# Altium schematic sheet Inresol_grid_inverter_EMI-Filter_GRID_UI_Rev3B.SchDoc
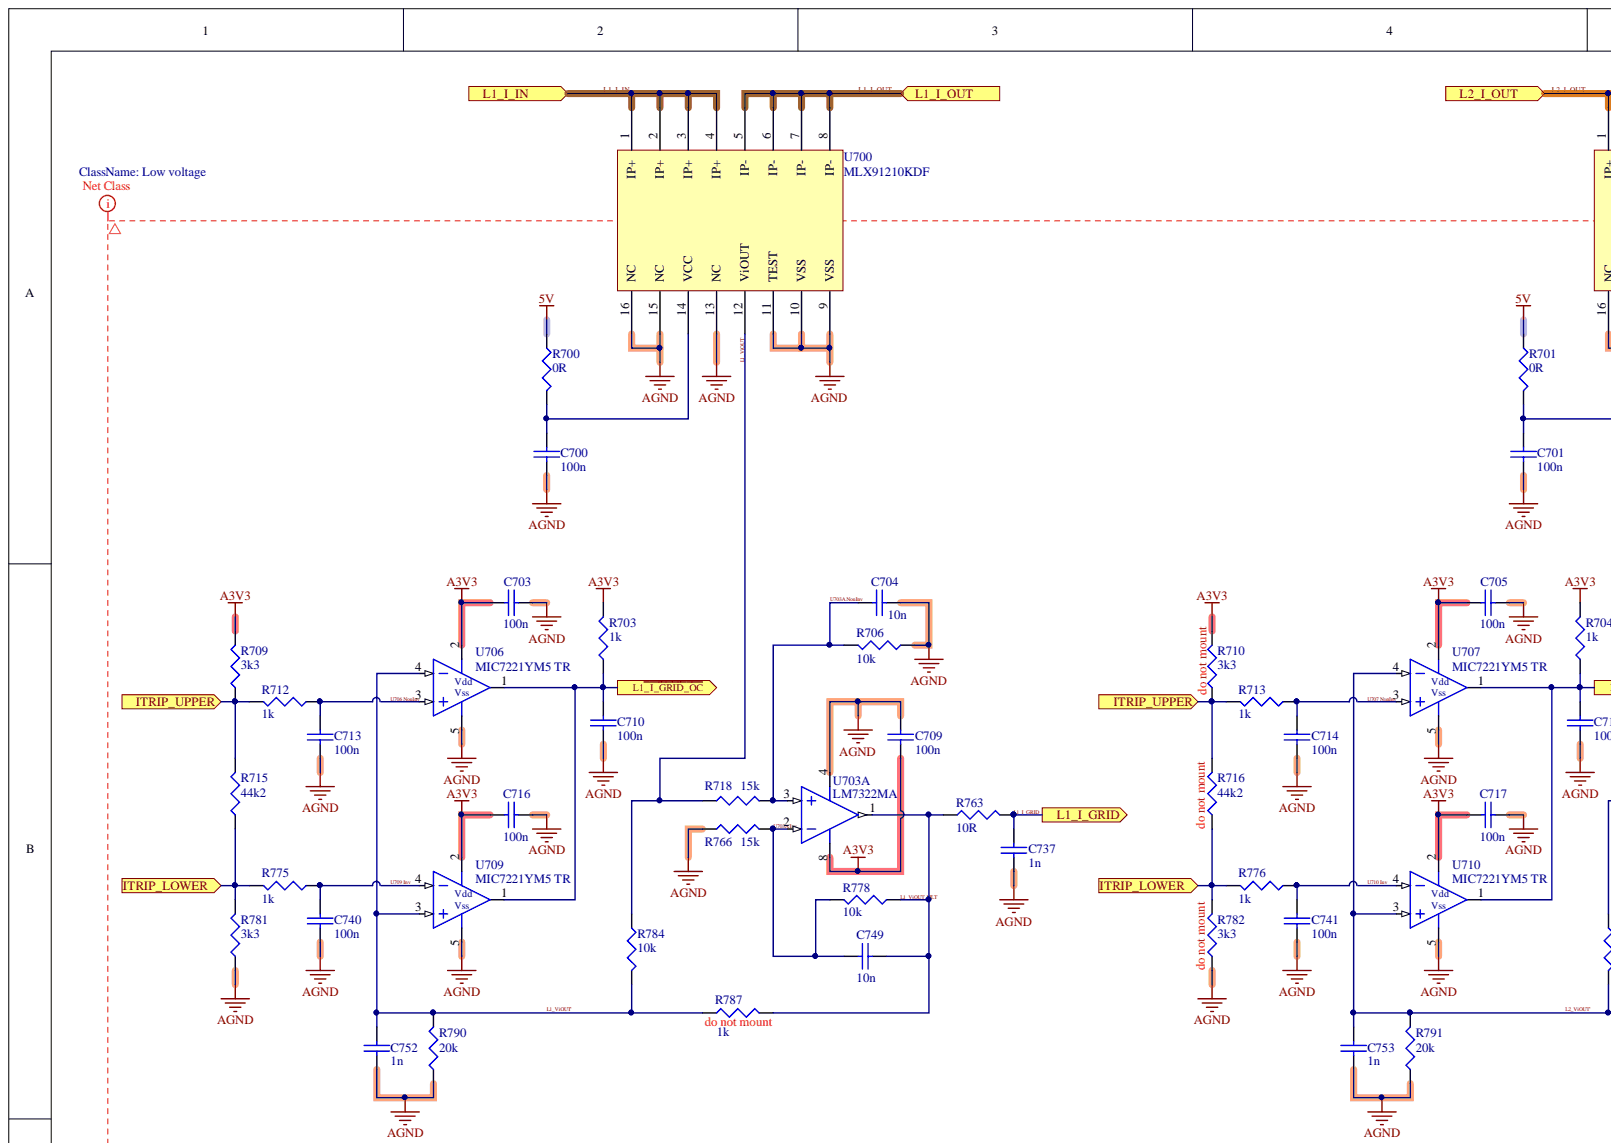
[diagram p0: Inresol_grid_inverter_EMI-Filter_GRID_UI_Rev3B.SchDoc, top left]
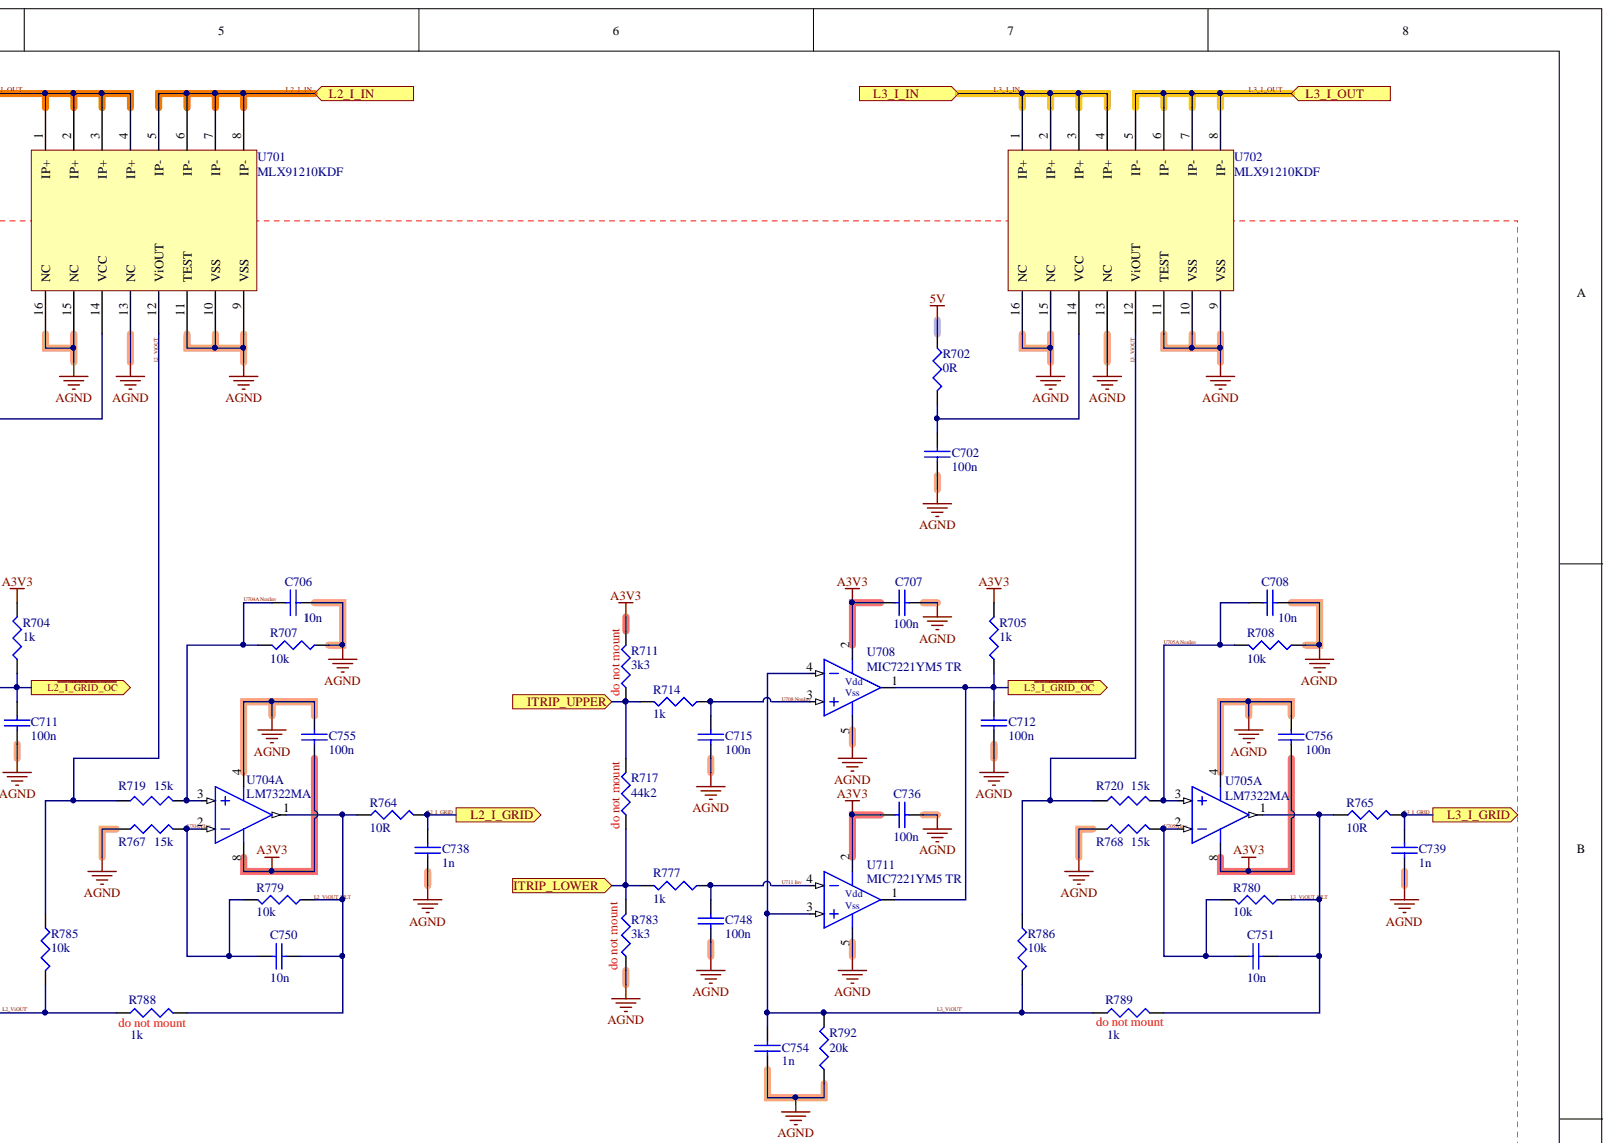
[diagram p1: Inresol_grid_inverter_EMI-Filter_GRID_UI_Rev3B.SchDoc, top right]
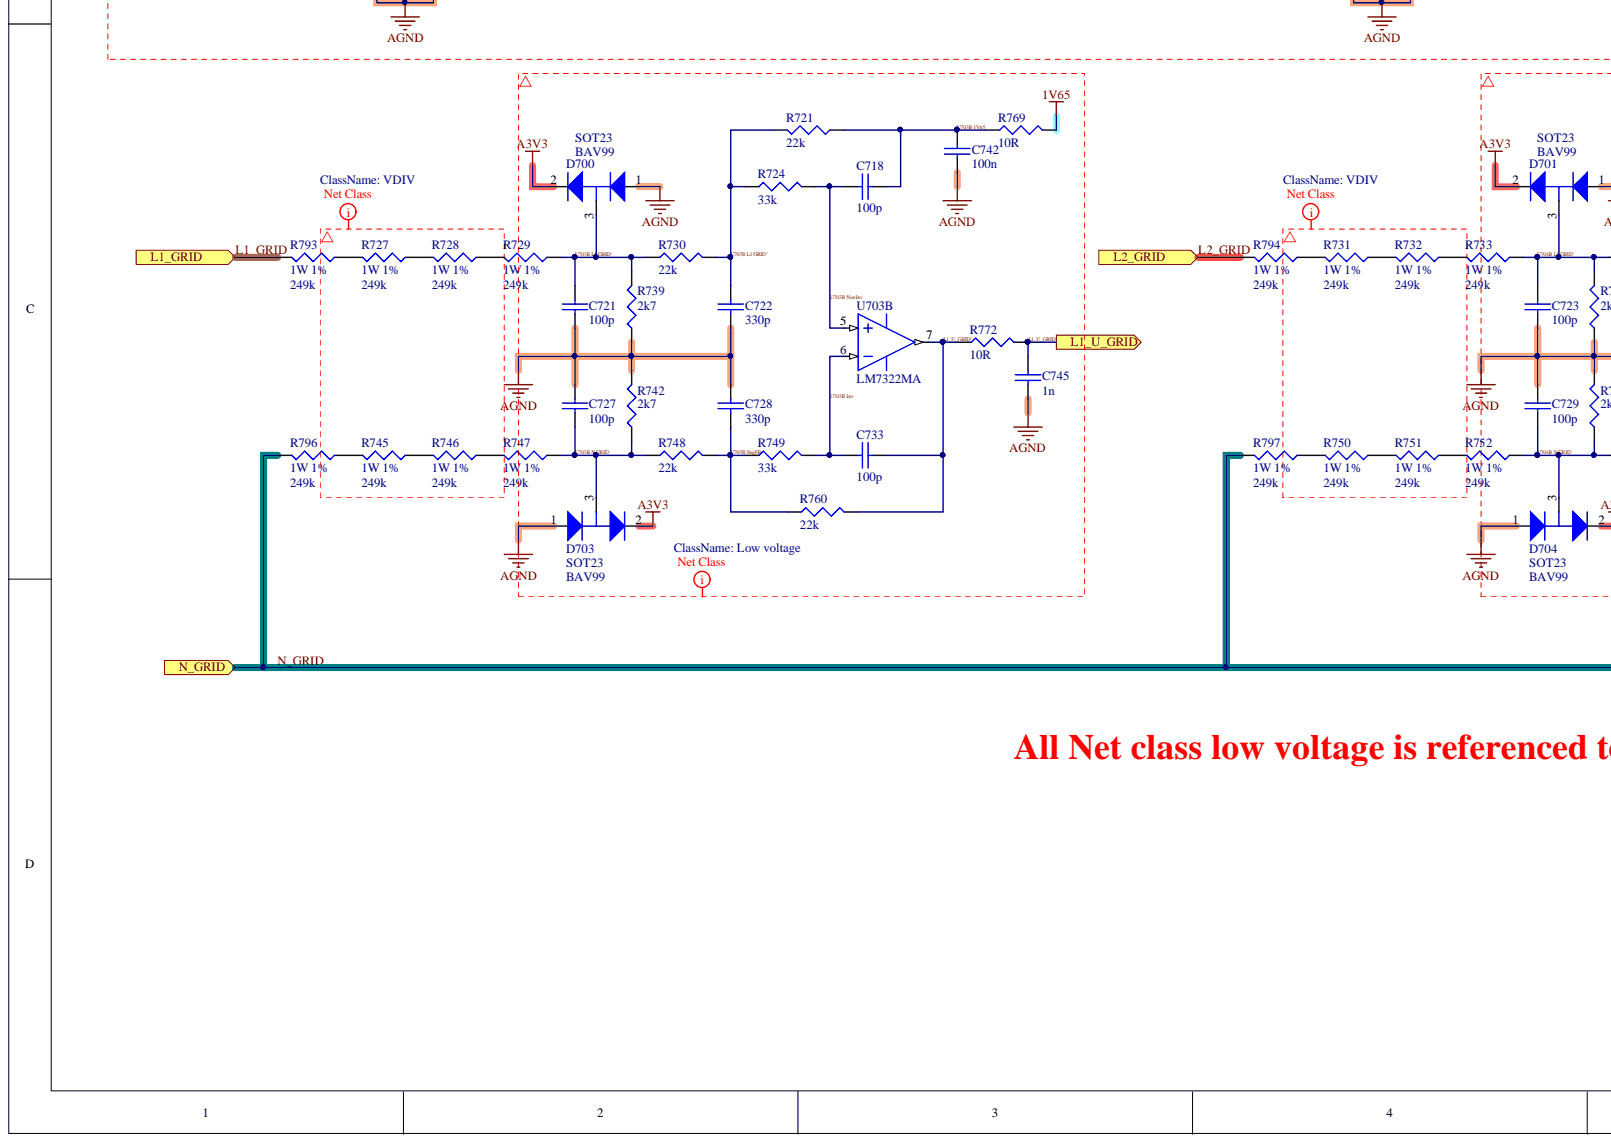
[diagram p2: Inresol_grid_inverter_EMI-Filter_GRID_UI_Rev3B.SchDoc, bottom left]
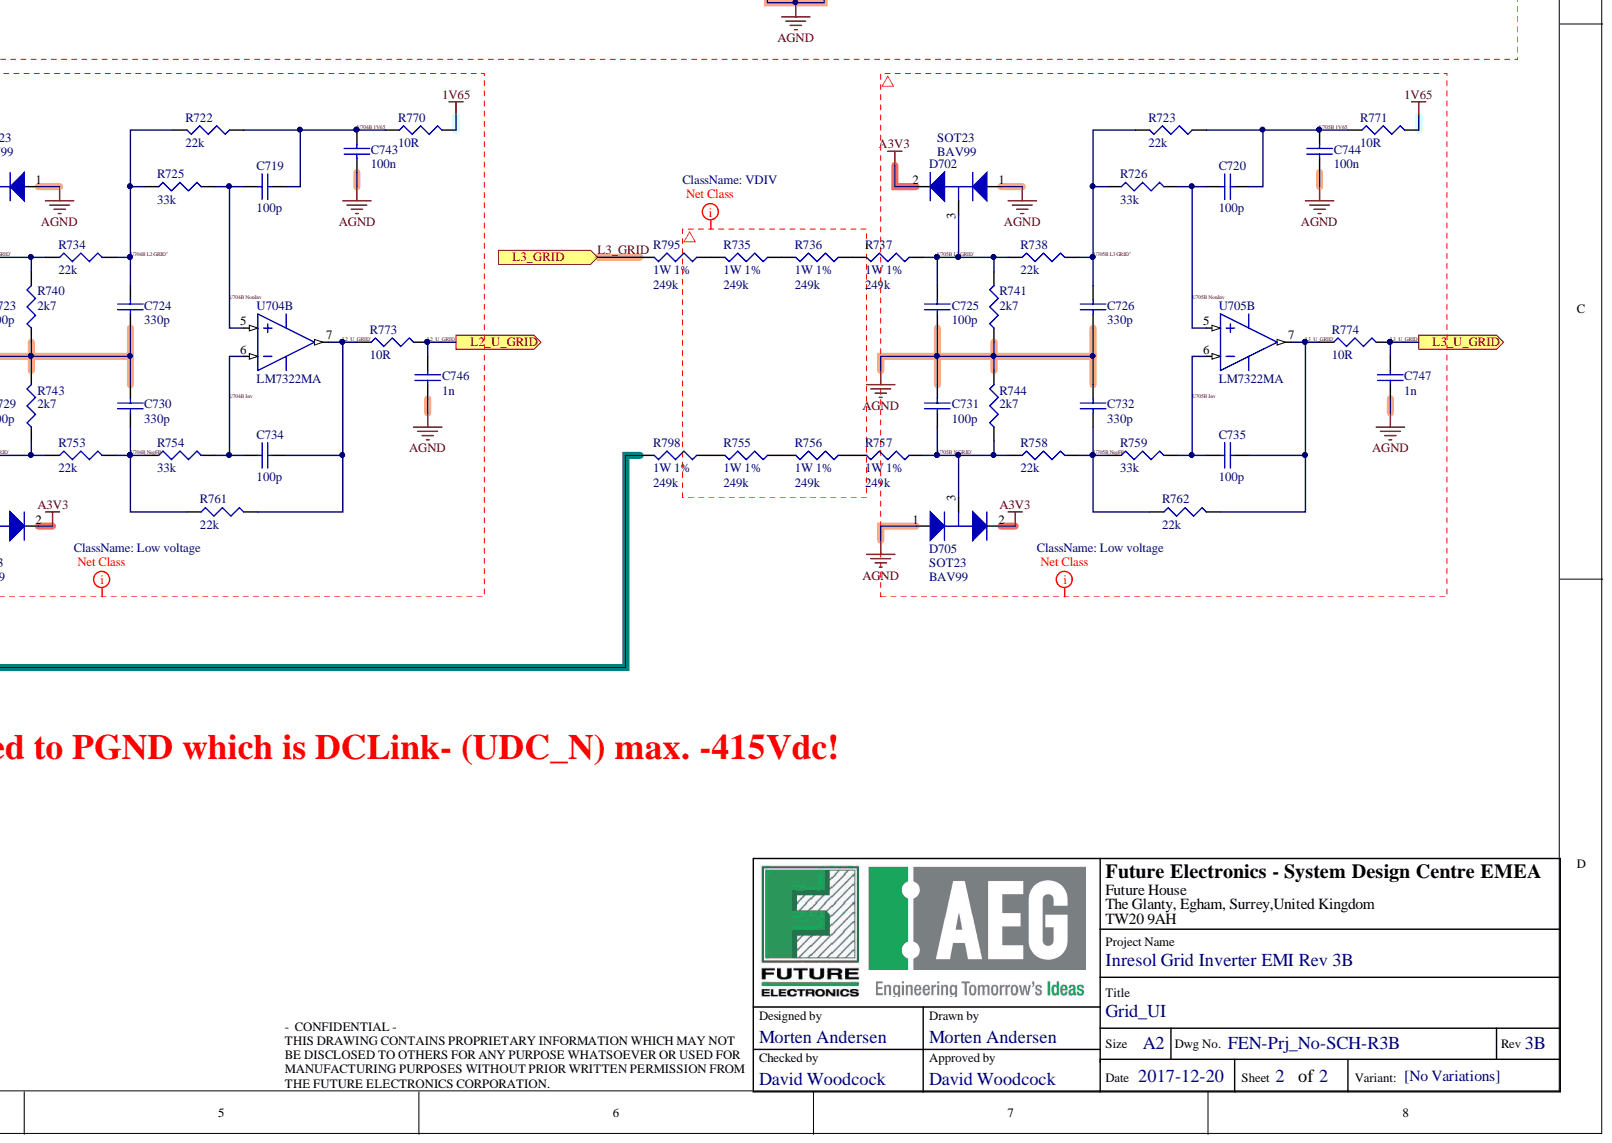
[diagram p3: Inresol_grid_inverter_EMI-Filter_GRID_UI_Rev3B.SchDoc, bottom right]

## Parts
C700  value 100n  [0603]  (hidden comment: C-100nF-50V-Cer-0603)
C701  value 100n  [0603]  (hidden comment: C-100nF-50V-Cer-0603)
C702  value 100n  [0603]  (hidden comment: C-100nF-50V-Cer-0603)
C703  value 100n  [0603]  (hidden comment: C-100nF-50V-Cer-0603)
C704  value 10n  [0603]  (hidden comment: C-10nF-25V-Cer-0603)
C705  value 100n  [0603]  (hidden comment: C-100nF-50V-Cer-0603)
C706  value 10n  [0603]  (hidden comment: C-10nF-25V-Cer-0603)
C707  value 100n  [0603]  (hidden comment: C-100nF-50V-Cer-0603)
C708  value 10n  [0603]  (hidden comment: C-10nF-25V-Cer-0603)
C709  value 100n  [0603]  (hidden comment: C-100nF-50V-Cer-0603)
C710  value 100n  [0603]  (hidden comment: C-100nF-50V-Cer-0603)
C711  value 100n  [0603]  (hidden comment: C-100nF-50V-Cer-0603)
C712  value 100n  [0603]  (hidden comment: C-100nF-50V-Cer-0603)
C713  value 100n  [0603]  (hidden comment: C-100nF-50V-Cer-0603)
C714  value 100n  [0603]  (hidden comment: C-100nF-50V-Cer-0603)
C715  value 100n  [0603]  (hidden comment: C-100nF-50V-Cer-0603)
C716  value 100n  [0603]  (hidden comment: C-100nF-50V-Cer-0603)
C717  value 100n  [0603]  (hidden comment: C-100nF-50V-Cer-0603)
C718  value 100p  [0603]  (hidden comment: C-100pF-25V-Cer-0603)
C719  value 100p  [0603]  (hidden comment: C-100pF-25V-Cer-0603)
C720  value 100p  [0603]  (hidden comment: C-100pF-25V-Cer-0603)
C721  value 100p  [0603]  (hidden comment: C-100pF-25V-Cer-0603)
C722  value 330p  [0603]  (hidden comment: C-330pF-25V-Cer-0603)
C723  value 100p  [0603]  (hidden comment: C-100pF-25V-Cer-0603)
C724  value 330p  [0603]  (hidden comment: C-330pF-25V-Cer-0603)
C725  value 100p  [0603]  (hidden comment: C-100pF-25V-Cer-0603)
C726  value 330p  [0603]  (hidden comment: C-330pF-25V-Cer-0603)
C727  value 100p  [0603]  (hidden comment: C-100pF-25V-Cer-0603)
C728  value 330p  [0603]  (hidden comment: C-330pF-25V-Cer-0603)
C729  value 100p  [0603]  (hidden comment: C-100pF-25V-Cer-0603)
C730  value 330p  [0603]  (hidden comment: C-330pF-25V-Cer-0603)
C731  value 100p  [0603]  (hidden comment: C-100pF-25V-Cer-0603)
C732  value 330p  [0603]  (hidden comment: C-330pF-25V-Cer-0603)
C733  value 100p  [0603]  (hidden comment: C-100pF-25V-Cer-0603)
C734  value 100p  [0603]  (hidden comment: C-100pF-25V-Cer-0603)
C735  value 100p  [0603]  (hidden comment: C-100pF-25V-Cer-0603)
C736  value 100n  [0603]  (hidden comment: C-100nF-50V-Cer-0603)
C737  value 1n  [0603]  (hidden comment: C-1nF-50V-Cer-0603)
C738  value 1n  [0603]  (hidden comment: C-1nF-50V-Cer-0603)
C739  value 1n  [0603]  (hidden comment: C-1nF-50V-Cer-0603)
C740  value 100n  [0603]  (hidden comment: C-100nF-50V-Cer-0603)
C741  value 100n  [0603]  (hidden comment: C-100nF-50V-Cer-0603)
C742  value 100n  [0603]  (hidden comment: C-100nF-50V-Cer-0603)
C743  value 100n  [0603]  (hidden comment: C-100nF-50V-Cer-0603)
C744  value 100n  [0603]  (hidden comment: C-100nF-50V-Cer-0603)
C745  value 1n  [0603]  (hidden comment: C-1nF-50V-Cer-0603)
C746  value 1n  [0603]  (hidden comment: C-1nF-50V-Cer-0603)
C747  value 1n  [0603]  (hidden comment: C-1nF-50V-Cer-0603)
C748  value 100n  [0603]  (hidden comment: C-100nF-50V-Cer-0603)
C749  value 10n  [0603]  (hidden comment: C-10nF-25V-Cer-0603)
C750  value 10n  [0603]  (hidden comment: C-10nF-25V-Cer-0603)
C751  value 10n  [0603]  (hidden comment: C-10nF-25V-Cer-0603)
C752  value 1n  [0603]  (hidden comment: C-1nF-50V-Cer-0603)
C753  value 1n  [0603]  (hidden comment: C-1nF-50V-Cer-0603)
C754  value 1n  [0603]  (hidden comment: C-1nF-50V-Cer-0603)
C755  value 100n  [0603]  (hidden comment: C-100nF-50V-Cer-0603)
C756  value 100n  [0603]  (hidden comment: C-100nF-50V-Cer-0603)
D700  value BAV99  [SOT23]  (hidden comment: D-SOT23-BAV99)
D701  value BAV99  [SOT23]  (hidden comment: D-SOT23-BAV99)
D702  value BAV99  [SOT23]  (hidden comment: D-SOT23-BAV99)
D703  value BAV99  [SOT23]  (hidden comment: D-SOT23-BAV99)
D704  value BAV99  [SOT23]  (hidden comment: D-SOT23-BAV99)
D705  value BAV99  [SOT23]  (hidden comment: D-SOT23-BAV99)
R700  value 0R  [0603]  (hidden comment: R-0R-100mW-0603)
R701  value 0R  [0603]  (hidden comment: R-0R-100mW-0603)
R702  value 0R  [0603]  (hidden comment: R-0R-100mW-0603)
R703  value 1k  [0603]  (hidden comment: R-1k-100mW-0603)
R704  value 1k  [0603]  (hidden comment: R-1k-100mW-0603)
R705  value 1k  [0603]  (hidden comment: R-1k-100mW-0603)
R706  value 10k  [0603]  (hidden comment: R-10k-100mW-0603)
R707  value 10k  [0603]  (hidden comment: R-10k-100mW-0603)
R708  value 10k  [0603]  (hidden comment: R-10k-100mW-0603)
R709  value 3k3  [0603]  (hidden comment: R-3.3k-100mW-0603)
R710  value 3k3  note: do not mount  [0603]  (hidden comment: R-3.3k-100mW-0603)  (no BOM)
R711  value 3k3  note: do not mount  [0603]  (hidden comment: R-3.3k-100mW-0603)  (no BOM)
R712  value 1k  [0603]  (hidden comment: R-1k-100mW-0603)
R713  value 1k  [0603]  (hidden comment: R-1k-100mW-0603)
R714  value 1k  [0603]  (hidden comment: R-1k-100mW-0603)
R715  value 44k2  [0603]  (hidden comment: R-44.2k-100mW -0603)
R716  value 44k2  note: do not mount  [0603]  (hidden comment: R-44.2k-100mW -0603)  (no BOM)
R717  value 44k2  note: do not mount  [0603]  (hidden comment: R-44.2k-100mW -0603)  (no BOM)
R718  value 15k  [0603]  (hidden comment: R-15k-100mW-0603)
R719  value 15k  [0603]  (hidden comment: R-15k-100mW-0603)
R720  value 15k  [0603]  (hidden comment: R-15k-100mW-0603)
R721  value 22k  [0603]  (hidden comment: R-22k-100mW-0603)
R722  value 22k  [0603]  (hidden comment: R-22k-100mW-0603)
R723  value 22k  [0603]  (hidden comment: R-22k-100mW-0603)
R724  value 33k  [0603]  (hidden comment: R-33k-100mW-0603)
R725  value 33k  [0603]  (hidden comment: R-33k-100mW-0603)
R726  value 33k  [0603]  (hidden comment: R-33k-100mW-0603)
R727  value 249k  Rating: 1W 1%  [2512]  (hidden comment: R-249k-1W-2512)
R728  value 249k  Rating: 1W 1%  [2512]  (hidden comment: R-249k-1W-2512)
R729  value 249k  Rating: 1W 1%  [2512]  (hidden comment: R-249k-1W-2512)
R730  value 22k  [0603]  (hidden comment: R-22k-100mW-0603)
R731  value 249k  Rating: 1W 1%  [2512]  (hidden comment: R-249k-1W-2512)
R732  value 249k  Rating: 1W 1%  [2512]  (hidden comment: R-249k-1W-2512)
R733  value 249k  Rating: 1W 1%  [2512]  (hidden comment: R-249k-1W-2512)
R734  value 22k  [0603]  (hidden comment: R-22k-100mW-0603)
R735  value 249k  Rating: 1W 1%  [2512]  (hidden comment: R-249k-1W-2512)
R736  value 249k  Rating: 1W 1%  [2512]  (hidden comment: R-249k-1W-2512)
R737  value 249k  Rating: 1W 1%  [2512]  (hidden comment: R-249k-1W-2512)
R738  value 22k  [0603]  (hidden comment: R-22k-100mW-0603)
R739  value 2k7  [0603]  (hidden comment: R-2.7k-100mW-0603)
R740  value 2k7  [0603]  (hidden comment: R-2.7k-100mW-0603)
R741  value 2k7  [0603]  (hidden comment: R-2.7k-100mW-0603)
R742  value 2k7  [0603]  (hidden comment: R-2.7k-100mW-0603)
R743  value 2k7  [0603]  (hidden comment: R-2.7k-100mW-0603)
R744  value 2k7  [0603]  (hidden comment: R-2.7k-100mW-0603)
R745  value 249k  Rating: 1W 1%  [2512]  (hidden comment: R-249k-1W-2512)
R746  value 249k  Rating: 1W 1%  [2512]  (hidden comment: R-249k-1W-2512)
R747  value 249k  Rating: 1W 1%  [2512]  (hidden comment: R-249k-1W-2512)
R748  value 22k  [0603]  (hidden comment: R-22k-100mW-0603)
R749  value 33k  [0603]  (hidden comment: R-33k-100mW-0603)
R750  value 249k  Rating: 1W 1%  [2512]  (hidden comment: R-249k-1W-2512)
R751  value 249k  Rating: 1W 1%  [2512]  (hidden comment: R-249k-1W-2512)
R752  value 249k  Rating: 1W 1%  [2512]  (hidden comment: R-249k-1W-2512)
R753  value 22k  [0603]  (hidden comment: R-22k-100mW-0603)
R754  value 33k  [0603]  (hidden comment: R-33k-100mW-0603)
R755  value 249k  Rating: 1W 1%  [2512]  (hidden comment: R-249k-1W-2512)
R756  value 249k  Rating: 1W 1%  [2512]  (hidden comment: R-249k-1W-2512)
R757  value 249k  Rating: 1W 1%  [2512]  (hidden comment: R-249k-1W-2512)
R758  value 22k  [0603]  (hidden comment: R-22k-100mW-0603)
R759  value 33k  [0603]  (hidden comment: R-33k-100mW-0603)
R760  value 22k  [0603]  (hidden comment: R-22k-100mW-0603)
R761  value 22k  [0603]  (hidden comment: R-22k-100mW-0603)
R762  value 22k  [0603]  (hidden comment: R-22k-100mW-0603)
R763  value 10R  [0603]  (hidden comment: R-10R-100mW-0603)
R764  value 10R  [0603]  (hidden comment: R-10R-100mW-0603)
R765  value 10R  [0603]  (hidden comment: R-10R-100mW-0603)
R766  value 15k  [0603]  (hidden comment: R-15k-100mW-0603)
R767  value 15k  [0603]  (hidden comment: R-15k-100mW-0603)
R768  value 15k  [0603]  (hidden comment: R-15k-100mW-0603)
R769  value 10R  [0603]  (hidden comment: R-10R-100mW-0603)
R770  value 10R  [0603]  (hidden comment: R-10R-100mW-0603)
R771  value 10R  [0603]  (hidden comment: R-10R-100mW-0603)
R772  value 10R  [0603]  (hidden comment: R-10R-100mW-0603)
R773  value 10R  [0603]  (hidden comment: R-10R-100mW-0603)
R774  value 10R  [0603]  (hidden comment: R-10R-100mW-0603)
R775  value 1k  [0603]  (hidden comment: R-1k-100mW-0603)
R776  value 1k  [0603]  (hidden comment: R-1k-100mW-0603)
R777  value 1k  [0603]  (hidden comment: R-1k-100mW-0603)
R778  value 10k  [0603]  (hidden comment: R-10k-100mW-0603)
R779  value 10k  [0603]  (hidden comment: R-10k-100mW-0603)
R780  value 10k  [0603]  (hidden comment: R-10k-100mW-0603)
R781  value 3k3  [0603]  (hidden comment: R-3.3k-100mW-0603)
R782  value 3k3  note: do not mount  [0603]  (hidden comment: R-3.3k-100mW-0603)  (no BOM)
R783  value 3k3  note: do not mount  [0603]  (hidden comment: R-3.3k-100mW-0603)  (no BOM)
R784  value 10k  [0603]  (hidden comment: R-10k-100mW-0603)
R785  value 10k  [0603]  (hidden comment: R-10k-100mW-0603)
R786  value 10k  [0603]  (hidden comment: R-10k-100mW-0603)
R787  value 1k  note: do not mount  [0603]  (hidden comment: R-1k-100mW-0603)  (no BOM)
R788  value 1k  note: do not mount  [0603]  (hidden comment: R-1k-100mW-0603)  (no BOM)
R789  value 1k  note: do not mount  [0603]  (hidden comment: R-1k-100mW-0603)  (no BOM)
R790  value 20k  [0603]  (hidden comment: R-20k-100mW-0603)
R791  value 20k  [0603]  (hidden comment: R-20k-100mW-0603)
R792  value 20k  [0603]  (hidden comment: R-20k-100mW-0603)
R793  value 249k  Rating: 1W 1%  [2512]  (hidden comment: R-249k-1W-2512)
R794  value 249k  Rating: 1W 1%  [2512]  (hidden comment: R-249k-1W-2512)
R795  value 249k  Rating: 1W 1%  [2512]  (hidden comment: R-249k-1W-2512)
R796  value 249k  Rating: 1W 1%  [2512]  (hidden comment: R-249k-1W-2512)
R797  value 249k  Rating: 1W 1%  [2512]  (hidden comment: R-249k-1W-2512)
R798  value 249k  Rating: 1W 1%  [2512]  (hidden comment: R-249k-1W-2512)
U700  Rating: MLX91210KDF  [SOIC16W]  (hidden comment: U- SOICW 16PIN-MLX91210KDF-EMEA-24-08-2017)
U701  Rating: MLX91210KDF  [SOIC16W]  (hidden comment: U- SOICW 16PIN-MLX91210KDF-EMEA-24-08-2017)
U702  Rating: MLX91210KDF  [SOIC16W]  (hidden comment: U- SOICW 16PIN-MLX91210KDF-EMEA-24-08-2017)
U703  value LM7322MA  [SO8]  (hidden comment: U-SOIC8-LM7322)  (parts A,B)
U704  value LM7322MA  [SO8]  (hidden comment: U-SOIC8-LM7322)  (parts A,B)
U705  value LM7322MA  [SO8]  (hidden comment: U-SOIC8-LM7322)  (parts A,B)
U706  U-SOT23_5-MIC7221YM5 TR  [SOT23_5]  (value not drawn)
U707  U-SOT23_5-MIC7221YM5 TR  [SOT23_5]  (value not drawn)
U708  U-SOT23_5-MIC7221YM5 TR  [SOT23_5]  (value not drawn)
U709  U-SOT23_5-MIC7221YM5 TR  [SOT23_5]  (value not drawn)
U710  MFR PN: MIC7221YM5 TR  [SOT23_5]  (hidden comment: U-SOT23_5-MIC7221YM5 TR)
U711  U-SOT23_5-MIC7221YM5 TR  [SOT23_5]  (value not drawn)

## Ports
ITRIP_LOWER, ITRIP_UPPER, L1_GRID, L1_I_GRID, L1_I_IN, L1_I_OUT, L1_U_GRID, L2_GRID, L2_I_GRID, L2_I_IN, L2_I_OUT, L2_U_GRID, L3_GRID, L3_I_GRID, L3_I_IN, L3_I_OUT, L3_U_GRID, N_GRID, ~{L1_I_GRID_OC}, ~{L2_I_GRID_OC}, ~{L3_I_GRID_OC}

## Nets
1V65: R769.2 R770.2 R771.2
5V: R700.2 R701.2 R702.2
A3V3: C703.1 C705.1 C707.1 C709.2 C716.1 C717.1 C736.1 C755.2 C756.2 D700.2 D701.2 D702.2 D703.2 D704.2 D705.2 R703.2 R704.2 R705.2 R709.2 R710.2 R711.2 U703.8 U704.8 U705.8 U706.2 U707.2 U708.2 U709.2 U710.2 U711.2
AGND: C700.2 C701.2 C702.2 C703.2 C704.2 C705.2 C706.2 C707.2 C708.2 C709.1 C710.1 C711.1 C712.1 C713.1 C714.1 C715.1 C716.2 C717.2 C721.2 C722.2 C723.2 C724.2 C725.2 C726.2 C727.1 C728.1 C729.1 C730.1 C731.1 C732.1 C736.2 C737.2 C738.2 C739.2 C740.1 C741.1 C742.2 C743.2 C744.2 C745.2 C746.2 C747.2 C748.1 C752.1 C753.1 C754.1 C755.1 C756.1 D700.1 D701.1 D702.1 D703.1 D704.1 D705.1 R706.1 R707.1 R708.1 R739.1 R740.1 R741.1 R742.2 R743.2 R744.2 R766.1 R767.1 R768.1 R781.1 R782.1 R783.1 R790.1 R791.1 R792.1 U700.9(VSS) U700.10(VSS) U700.11(TEST) U700.13(NC) U700.15(NC) U700.16(NC) U701.9(VSS) U701.10(VSS) U701.11(TEST) U701.13(NC) U701.15(NC) U701.16(NC) U702.9(VSS) U702.10(VSS) U702.11(TEST) U702.13(NC) U702.15(NC) U702.16(NC) U703.4 U704.4 U705.4 U706.5 U707.5 U708.5 U709.5 U710.5 U711.5
ITRIP_LOWER: R715.1 R716.1 R717.1 R775.2 R776.2 R777.2 R781.2 R782.2 R783.2 ; port ITRIP_LOWER
ITRIP_UPPER: R709.1 R710.1 R711.1 R712.2 R713.2 R714.2 R715.2 R716.2 R717.2 ; port ITRIP_UPPER
L1_GRID: R793.2 ; port L1_GRID
L1_I_GRID: C737.1 R763.2 ; port L1_I_GRID
L1_I_IN: U700.1(IP+) U700.2(IP+) U700.3(IP+) U700.4(IP+) ; port L1_I_IN
L1_I_OUT: U700.5(IP-) U700.6(IP-) U700.7(IP-) U700.8(IP-) ; port L1_I_OUT
L1_U_GRID: C745.1 R772.2 ; port L1_U_GRID
L1_U_GRID': C733.1 R760.2 R772.1 U703.7
L1_VIOUT: R718.1 R784.1 U700.12(ViOUT)
L1_VIOUT': C752.2 R784.2 R787.1 R790.2 U706.4 U709.3
L1_VIOUT_FILT: C749.2 R763.1 R778.1 R787.2 U703.1
L2_GRID: R794.2 ; port L2_GRID
L2_I_GRID: C738.1 R764.2 ; port L2_I_GRID
L2_I_IN: U701.5(IP-) U701.6(IP-) U701.7(IP-) U701.8(IP-) ; port L2_I_IN
L2_I_OUT: U701.1(IP+) U701.2(IP+) U701.3(IP+) U701.4(IP+) ; port L2_I_OUT
L2_U_GRID: C746.1 R773.2 ; port L2_U_GRID
L2_U_GRID': C734.1 R761.2 R773.1 U704.7
L2_VIOUT: R719.1 R785.1 U701.12(ViOUT)
L2_VIOUT': C753.2 R785.2 R788.1 R791.2 U707.4 U710.3
L2_VIOUT_FILT: C750.2 R764.1 R779.1 R788.2 U704.1
L3_GRID: R795.2 ; port L3_GRID
L3_I_GRID: C739.1 R765.2 ; port L3_I_GRID
L3_I_IN: U702.1(IP+) U702.2(IP+) U702.3(IP+) U702.4(IP+) ; port L3_I_IN
L3_I_OUT: U702.5(IP-) U702.6(IP-) U702.7(IP-) U702.8(IP-) ; port L3_I_OUT
L3_U_GRID: C747.1 R774.2 ; port L3_U_GRID
L3_U_GRID': C735.1 R762.2 R774.1 U705.7
L3_VIOUT: R720.1 R786.1 U702.12(ViOUT)
L3_VIOUT': C754.2 R786.2 R789.1 R792.2 U708.4 U711.3
L3_VIOUT_FILT: C751.2 R765.1 R780.1 R789.2 U705.1
~{L1_I_GRID_OC}: C710.2 R703.1 U706.1 U709.1 ; port ~{L1_I_GRID_OC}
~{L2_I_GRID_OC}: C711.2 R704.1 U707.1 U710.1 ; port ~{L2_I_GRID_OC}
~{L3_I_GRID_OC}: C712.2 R705.1 U708.1 U711.1 ; port ~{L3_I_GRID_OC}
N_GRID: R796.2 R797.2 R798.2 ; port N_GRID
U703A INV: C749.1 R766.2 R778.2 U703.2
U703A NONINV: C704.1 R706.2 R718.2 U703.3
U703B 1V65: C718.1 C742.1 R721.1 R769.1
U703B INV: C733.2 R749.2 U703.6
U703B L1 GRID': C721.1 D700.3 R729.1 R730.1 R739.2
U703B L1 GRID'': C722.1 R721.2 R724.1 R730.2
U703B N GRID': C727.2 D703.3 R742.1 R747.1 R748.1
U703B NEGFB: C728.2 R748.2 R749.1 R760.1
U703B NONINV: C718.2 R724.2 U703.5
U704A INV: C750.1 R767.2 R779.2 U704.2
U704A NONINV: C706.1 R707.2 R719.2 U704.3
U704B 1V65: C719.1 C743.1 R722.1 R770.1
U704B INV: C734.2 R754.2 U704.6
U704B L2 GRID': C723.1 D701.3 R733.1 R734.1 R740.2
U704B L2 GRID'': C724.1 R722.2 R725.1 R734.2
U704B N GRID': C729.2 D704.3 R743.1 R752.1 R753.1
U704B NEGFB: C730.2 R753.2 R754.1 R761.1
U704B NONINV: C719.2 R725.2 U704.5
U705A INV: C751.1 R768.2 R780.2 U705.2
U705A NONINV: C708.1 R708.2 R720.2 U705.3
U705B 1V65: C720.1 C744.1 R723.1 R771.1
U705B INV: C735.2 R759.2 U705.6
U705B L3 GRID': C725.1 D702.3 R737.1 R738.1 R741.2
U705B L3 GRID'': C726.1 R723.2 R726.1 R738.2
U705B N GRID': C731.2 D705.3 R744.1 R757.1 R758.1
U705B NEGFB: C732.2 R758.2 R759.1 R762.1
U705B NONINV: C720.2 R726.2 U705.5
U706 NONINV: C713.2 R712.1 U706.3
U707 NONINV: C714.2 R713.1 U707.3
U708 NONINV: C715.2 R714.1 U708.3
U709 INV: C740.2 R775.1 U709.4
U710 INV: C741.2 R776.1 U710.4
U711 INV: C748.2 R777.1 U711.4
NetC700_1: C700.1 R700.1 U700.14(VCC)
NetC701_1: C701.1 R701.1 U701.14(VCC)
NetC702_1: C702.1 R702.1 U702.14(VCC)
NetR727_1: R727.1 R728.2
NetR727_2: R727.2 R793.1
NetR728_1: R728.1 R729.2
NetR731_1: R731.1 R732.2
NetR731_2: R731.2 R794.1
NetR732_1: R732.1 R733.2
NetR735_1: R735.1 R736.2
NetR735_2: R735.2 R795.1
NetR736_1: R736.1 R737.2
NetR745_1: R745.1 R746.2
NetR745_2: R745.2 R796.1
NetR746_1: R746.1 R747.2
NetR750_1: R750.1 R751.2
NetR750_2: R750.2 R797.1
NetR751_1: R751.1 R752.2
NetR755_1: R755.1 R756.2
NetR755_2: R755.2 R798.1
NetR756_1: R756.1 R757.2
N00037:
N00038:
N00039:
N00040:
N00041:
N00042:
N00043:

## Library data of the parts
C700-C703 C705 C707 C709-C717 C736 C740-C744 C748 C755 C756 = C-100nF-50V-Cer-0603: Cap 0603 SM X7R 50V 10%; MFR: AVX; MFR PN: 06035C104K4T2A; Future PN (CT): 06035C104K4TN-CT; Future PN (TR): 06035C104K4TN; Rating: 50V 10%; Component Kind: Standard; ComponentLink1Description: Datasheet; ComponentLink1URL: http://www.avx.com/docs/Catalogs/cx7r.pdf; Library Name: EMEA CAPACITORS_FEB2016.SchLib; Verified: AD030615
C704 C706 C708 C749-C751 = C-10nF-25V-Cer-0603: Cap 0603 SM X7R 25V 10%; MFR: AVX; MFR PN: 06033C103KAT2A; Future PN (CT): 06033C103KATN-CT; Future PN (TR): 06033C103KATN; Rating: 25V 10%; Component Kind: Standard; ComponentLink1Description: Datasheet; ComponentLink1URL: http://www.avx.com/docs/Catalogs/cx7r.pdf; Library Name: Future Capacitors.SCHLIB; Verified: AD030615
C718-C721 C723 C725 C727 C729 C731 C733-C735 = C-100pF-25V-Cer-0603: Cap 0603 SM X7R 25V 10%; MFR: AVX; MFR PN: 06033C101KAT2A; Future PN (CT): 06033C101KATN-CT; Future PN (TR): 06033C101KATN; Rating: 25V 10%; Component Kind: Standard; ComponentLink1Description: Datasheet; ComponentLink1URL: http://www.avx.com/docs/Catalogs/cx7r.pdf; Library Name: Future Capacitors.SCHLIB; Verified: AD030615
C722 C724 C726 C728 C730 C732 = C-330pF-25V-Cer-0603: Cap 0603 SM X7R 25V 1%; MFR: AVX; MFR PN: 06033A331FAT2A; Future PN (CT): TBD; Future PN (TR): 06033A331FATN; Rating: 25V 1%; Component Kind: Standard; ComponentLink1Description: Datasheet; ComponentLink1URL: http://www.avx.com/docs/Catalogs/ccog.pdf; Library Name: Future Capacitors.SCHLIB; Verified: AD030615
C737-C739 C745-C747 C752-C754 = C-1nF-50V-Cer-0603: Cap 0603 SM X7R 50V 10%; MFR: VISHAY; MFR PN: VJ0603Y102KXACW1BC; Future PN (CT): 06035C102KATN-CT; Future PN (TR): 06035C102KATN; Rating: 50V 10%; Component Kind: Standard; ComponentLink1Description: Datasheet; ComponentLink1URL: http://www.vishay.com/docs/28548/vjw1bcbascomseries.pdf; Library Name: Future Capacitors.SCHLIB; Verified: AD030615
D700-D705 = D-SOT23-BAV99: Diode, Silicon Dual connected in series SOT23; MFR: DIO; Future PN (CT): BAV99LT1-CT; Future PN (TR): BAV99-7-F; Rating: 75V 300mA; Component Kind: Standard; ComponentLink1Description: Datasheet; ComponentLink1URL: http://www.diodes.com/datasheets/ds12007.pdf; Library Name: Future Semiconductors.SCHLIB; MFR PN: BAV99-7-F
R700-R702 = R-0R-100mW-0603: Res 0603 SM 100mW; MFR: ROHM; MFR PN: MCR03EZPJ000; Future PN (CT): CR0603-0000JTR-R-CT; Future PN (TR): CR0603-0000JTR-R; Component Kind: Standard; ComponentLink1Description: Datasheet; ComponentLink1URL: http://www.rohm.com/products/databook/r/pdf/mcr03.pdf; Library Name: Future Resistors.SCHLIB; Rating: 100mW
R703-R705 R712-R714 R775-R777 R787-R789 = R-1k-100mW-0603: Res 0603 SM 100mW 1% 100ppm; MFR: YAGEO; MFR PN: RC0603FR-071KL; Future PN (CT): CR0603-1001FTR-CT; Future PN (TR): CR0603-1001FTR; ComponentLink1Description: Datasheet; ComponentLink1URL: http://www.yageo.com/documents/recent/PYu-RC0603_51_RoHS_L_4.pdf; Library Name: EMEA RESISTORS_FEB2016.SchLib; Rating: 100mW 1%
  R703-R705 R712-R714 R775-R777: Component Kind: Standard
R706-R708 R778-R780 R784-R786 = R-10k-100mW-0603: Res 0603 SM 100mW 1% 100ppm; MFR: YAGEO; MFR PN: RC0603FR-0710KL; Future PN (CT): CR0603-1002FTR-CT; Future PN (TR): CR0603-1002FTR; Component Kind: Standard; ComponentLink1Description: Datasheet; ComponentLink1URL: http://www.yageo.com/documents/recent/PYu-RC0603_51_RoHS_L_4.pdf; Library Name: Future Resistors.SCHLIB; Rating: 100mW 1%
R709-R711 R781-R783 = R-3.3k-100mW-0603: Res 0603 SM 100mW 1% 100ppm; MFR: YAGEO; MFR PN: RC0603FR-073K3L; Future PN (CT): CR0603-3301FTR-CT; Future PN (TR): CR0603-3301FTR; ComponentLink1Description: Datasheet; ComponentLink1URL: http://www.yageo.com/documents/recent/PYu-RC0603_51_RoHS_L_4.pdf; Library Name: Future Resistors.SCHLIB; Rating: 100mW 1%
  R709 R781: Component Kind: Standard
R715-R717 = R-44.2k-100mW -0603: Res 0603 SM 100mW 1% 100ppm; MFR: VISHAY; MFR PN: CRCW060344K2FKEA; Future PN (CT): CR0603-4422FTR-CT; Future PN (TR): CR0603-4422FTR; ComponentLink1Description: Datasheet; ComponentLink1URL: http://www.vishay.com/docs/20035/dcrcwe3.pdf; Library Name: Future Resistors.SCHLIB; Rating: 100mW 1%
  R715: Component Kind: Standard
R718-R720 R766-R768 = R-15k-100mW-0603: Res 0603 SM 100mW 1% 100ppm; MFR: YAGEO; MFR PN: RC0603FR-0715KL; Future PN (CT): CR0603-1502FTR-CT; Future PN (TR): CR0603-1502FTR; Component Kind: Standard; ComponentLink1Description: Datasheet; ComponentLink1URL: http://www.yageo.com/pdf/yageo/Yu-RC0603_51_PbFree_L_2.pdf; Library Name: Future Resistors.SCHLIB; Rating: 100mW 1%
R721-R723 R730 R734 R738 R748 R753 R758 R760-R762 = R-22k-100mW-0603: Res 0603 SM 100mW 1% 100ppm; MFR: YAGEO; MFR PN: RC0603FR-0722KL; Future PN (CT): CR0603-2202FTR-CT; Future PN (TR): CR0603-2202FTR; Component Kind: Standard; ComponentLink1Description: Datasheet; ComponentLink1URL: http://www.yageo.com/documents/recent/PYu-RC0603_51_RoHS_L_4.pdf; Library Name: Future Resistors.SCHLIB; Rating: 100mW 1%
R724-R726 R749 R754 R759 = R-33k-100mW-0603: Res 0603 SM 100mW 1% 100ppm; MFR: YAGEO; MFR PN: RC0603FR-0733KL; Future PN (CT): CR0603-3302FTR-CT; Future PN (TR): CR0603-3302FTR; Component Kind: Standard; ComponentLink1Description: Datasheet; ComponentLink1URL: http://www.yageo.com/documents/recent/PYu-RC0603_51_RoHS_L_4.pdf; Library Name: Future Resistors.SCHLIB; Rating: 100mW 1%
R727-R729 R731-R733 R735-R737 R745-R747 R750-R752 R755-R757 R793-R798 = R-249k-1W-2512-EMEA-25-08-2017: Res 2512 249k SM 1W 1%; MFR: Vishay; MFR PN: CRCW2512249KFKEG; Future PN (CT): TBD; Component Kind: Standard; ComponentLink1Description: Datasheet; ComponentLink1URL: http://www.vishay.com/doc?20035; Future PN (TR): TBD; Library Name: Inresol_grid_inverter.SchLib
R739-R744 = R-2.7k-100mW-0603: Res 0603 SM 100mW 1% 100ppm; MFR: YAGEO; MFR PN: RC0603FR-072K7L; Future PN (CT): CR0603-2701FTR-CT; Future PN (TR): CR0603-2701FTR; Component Kind: Standard; ComponentLink1Description: Datasheet; ComponentLink1URL: http://www.yageo.com/pdf/yageo/Yu-RC0603_51_PbFree_L_2.pdf; Library Name: Future Resistors.SCHLIB; Rating: 100mW 1%
R763-R765 R769-R774 = R-10R-100mW-0603: Res 0603 SM 100mW 1% 100ppm; MFR: YAGEO; MFR PN: RC0603FR-0710RL; Future PN (CT): CR0603-10R0FTR-CT; Future PN (TR): CR0603-10R0FTR; Component Kind: Standard; ComponentLink1Description: Datasheet; ComponentLink1URL: http://www.yageo.com/documents/recent/PYu-RC0603_51_RoHS_L_4.pdf; Library Name: Future Resistors.SCHLIB; Rating: 100mW 1%
R790-R792 = R-20k-100mW-0603: Res 0603 SM 100mW 1% 100ppm; MFR: YAGEO; MFR PN: RC0603FR-0720KL; Future PN (CT): CR0603-2002FTR-CT; Future PN (TR): CR0603-2002FTR; Component Kind: Standard; ComponentLink1Description: Datasheet; ComponentLink1URL: http://www.yageo.com/documents/recent/PYu-RC0603_51_RoHS_L_4.pdf; Library Name: Future Resistors.SCHLIB; Rating: 100mW 1%
U700-U702 = U- SOICW 16PIN-MLX91210KDF-EMEA-24-08-2017: MLX91210KDF ISOLATED CURRENT SENSOR; MFR: Melexis; MFR PN: MLX91210KDF-CAS-102-RE; APPR'D: Raised EMEA 21/09/2016; ComponentLink1 Description: *Datasheet; Future PN (CT): *tba; Future PN (TR): tba; Component Kind: Standard; Componentlink1 URL: https://www.melexis.com/-/media/files/documents/datasheets/mlx91210-datasheet-melexis.pdf; Library Name: Inresol_grid_inverter.SchLib
U703-U705 = U-SOIC8-LM7322: IC, Rail-to-Rail input and output Dual OpAmp LM7322MA; MFR: Fairchild; MFR PN: LM7322MAX/NOPB; Apr'd: RAISED EMEA 16TH NOV 2013; Rating: 5V; Component Kind: Standard; ComponentLink1Description: Datasheet; ComponentLink1URL: http://www.ti.com/lit/ds/symlink/lm7321.pdf; Library Name: Inresol_grid_inverter_EMI-Filter_Rev3B.SchLib
U706-U711 = U-SOT23_5-MIC7221YM5 TR: IC, Rail to Rail Input Comparator wt Open-Drain Output; MFR: MICREL; Apr'd: YES; Future PN (CT): MIC7221BM5TR-CT; Future PN (TR): MIC7221BM5TR; Rating: 2-10V; Component Kind: Standard; ComponentLink1Description: Datasheet; ComponentLink1URL: http://www.micrel.com/_PDF/mic7211.pdf; Library Name: Future Semiconductors.SCHLIB
  U706-U709 U711: MFR PN: MIC7221YM5 TR
